# Altium schematic sheet PIC.SchDoc
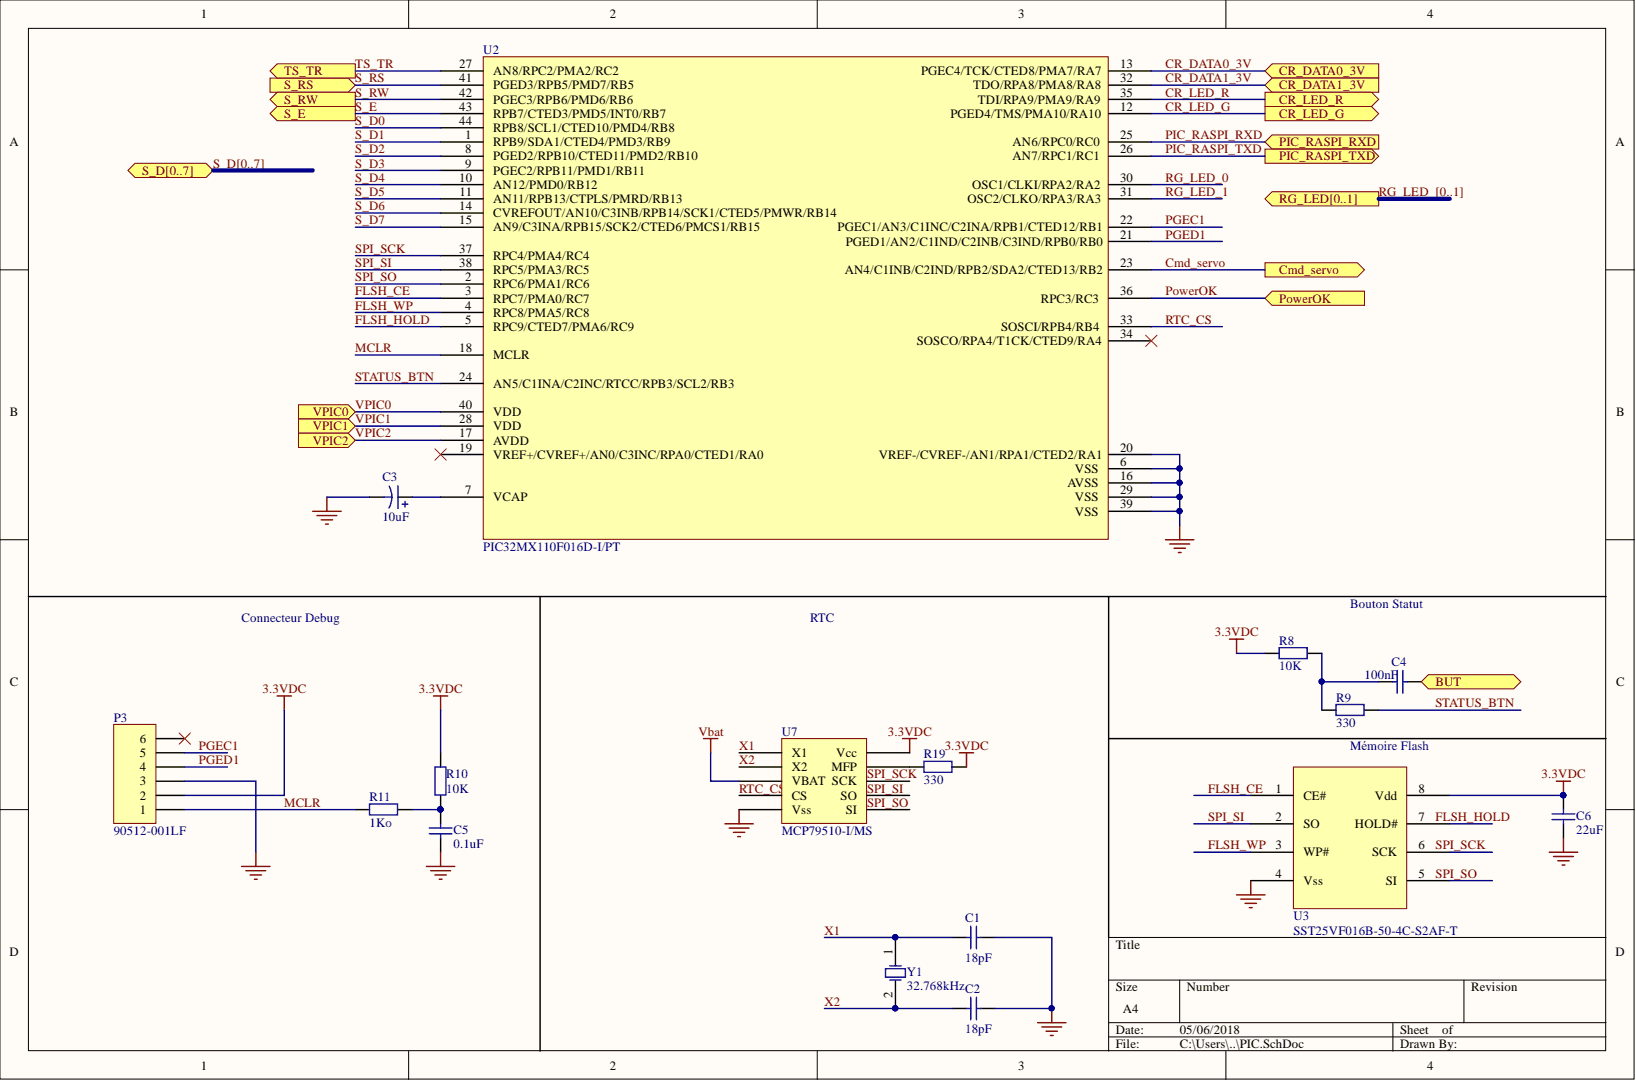
[diagram p0: PIC.SchDoc]

## Parts
C1  18pF  [0603]
C2  18pF  [0603]
C3  10uF  [C3]
C4  100nF  [0603]
C5  0.1uF  [0603]
C6  22uF  [0603]
P3  90512-001LF  [RJ12]
R8  10K  [0603]
R9  330  [0603]
R10  10K  [0603]
R11  1Ko  [0603]
R19  330  [0603]
U2  PIC32MX110F016D-I/PT  [TQFP-44_L]  (value not drawn)
U3  SST25VF016B-50-4C-S2AF-T  [SOIC208-8_L]
U7  MCP79510-I/MS  [MICROCHIP MSOP-10 UN]
Y1  32.768kHz  [QUARTZ32KHz]

## Ports
BUT, Cmd_servo, CR_DATA0_3V, CR_DATA1_3V, CR_LED_G, CR_LED_R, PIC_RASPI_RXD, PIC_RASPI_TXD, PowerOK, RG_LED0, RG_LED1, RG_LED[0..1], S_D0, S_D1, S_D2, S_D3, S_D4, S_D5, S_D6, S_D7, S_D[0..7], S_E, S_RS, S_RW, TS_TR, VPIC0, VPIC1, VPIC2

## Nets
3.3VDC: C6.2 P3.2 R8.1 R10.2 R19.2 U3.8(Vdd) U7.10(Vcc)
GND: C1.1 C2.1 C3.2 C5.1 C6.1 P3.3 U2.6(VSS) U2.16(AVSS) U2.20(VREF-/CVREF-/AN1/RPA1/CTED2/RA1) U2.29(VSS) U2.39(VSS) U3.4(Vss) U7.5(Vss)
Vbat: U7.3(VBAT)
BUT: C4.2 ; port BUT
Cmd_servo: U2.23(AN4/C1INB/C2IND/RPB2/SDA2/CTED13/RB2) ; port Cmd_servo
CR_DATA0_3V: U2.13(PGEC4/TCK/CTED8/PMA7/RA7) ; port CR_DATA0_3V
CR_DATA1_3V: U2.32(TDO/RPA8/PMA8/RA8) ; port CR_DATA1_3V
CR_LED_G: U2.12(PGED4/TMS/PMA10/RA10) ; port CR_LED_G
CR_LED_R: U2.35(TDI/RPA9/PMA9/RA9) ; port CR_LED_R
FLSH_CE: U2.3(RPC7/PMA0/RC7) U3.1(CE#)
FLSH_HOLD: U2.5(RPC9/CTED7/PMA6/RC9)
FLSH_WP: U2.4(RPC8/PMA5/RC8) U3.3(WP#)
MCLR: P3.1 R11.2 U2.18(MCLR)
PGEC1: P3.5 U2.22(PGEC1/AN3/C1INC/C2INA/RPB1/CTED12/RB1)
PGED1: P3.4 U2.21(PGED1/AN2/C1IND/C2INB/C3IND/RPB0/RB0)
PIC_RASPI_RXD: U2.25(AN6/RPC0/RC0) ; port PIC_RASPI_RXD
PIC_RASPI_TXD: U2.26(AN7/RPC1/RC1) ; port PIC_RASPI_TXD
PowerOK: U2.36(RPC3/RC3) ; port PowerOK
RG_LED_0: U2.30(OSC1/CLKI/RPA2/RA2) ; port RG_LED[0..1]  [also labelled RG_LED_]
RG_LED_1: U2.31(OSC2/CLKO/RPA3/RA3) ; port RG_LED[0..1]  [also labelled RG_LED_]
RTC_CS: U2.33(SOSCI/RPB4/RB4) U7.4(CS)
S_D0: U2.44(RPB8/SCL1/CTED10/PMD4/RB8) ; port S_D[0..7]  [also labelled S_D]
S_D1: U2.1(RPB9/SDA1/CTED4/PMD3/RB9) ; port S_D[0..7]  [also labelled S_D]
S_D2: U2.8(PGED2/RPB10/CTED11/PMD2/RB10) ; port S_D[0..7]  [also labelled S_D]
S_D3: U2.9(PGEC2/RPB11/PMD1/RB11) ; port S_D[0..7]  [also labelled S_D]
S_D4: U2.10(AN12/PMD0/RB12) ; port S_D[0..7]  [also labelled S_D]
S_D5: U2.11(AN11/RPB13/CTPLS/PMRD/RB13) ; port S_D[0..7]  [also labelled S_D]
S_D6: U2.14(CVREFOUT/AN10/C3INB/RPB14/SCK1/CTED5/PMWR/RB14) ; port S_D[0..7]  [also labelled S_D]
S_D7: U2.15(AN9/C3INA/RPB15/SCK2/CTED6/PMCS1/RB15) ; port S_D[0..7]  [also labelled S_D]
S_E: U2.43(RPB7/CTED3/PMD5/INT0/RB7) ; port S_E
S_RS: U2.41(PGED3/RPB5/PMD7/RB5) ; port S_RS
S_RW: U2.42(PGEC3/RPB6/PMD6/RB6) ; port S_RW
SPI_SCK: U2.37(RPC4/PMA4/RC4)
SPI_SI: U2.38(RPC5/PMA3/RC5) U3.2(SO)
SPI_SO: U2.2(RPC6/PMA1/RC6)
STATUS_BTN: R9.2 U2.24(AN5/C1INA/C2INC/RTCC/RPB3/SCL2/RB3)
TS_TR: U2.27(AN8/RPC2/PMA2/RC2) ; port TS_TR
VPIC0: U2.40(VDD) ; port VPIC0
VPIC1: U2.28(VDD) ; port VPIC1
VPIC2: U2.17(AVDD) ; port VPIC2
X1: C1.2 U7.1(X1) Y1.1
X2: C2.2 U7.2(X2) Y1.2
NetC3_1: C3.1 U2.7(VCAP)
NetC4_1: C4.1 R8.2 R9.1
NetC5_2: C5.2 R10.1 R11.1
NetR19_1: R19.1 U7.9(MFP)

Not connected: P3 6; U2 19(VREF+/CVREF+/AN0/C3INC/RPA0/CTED1/RA0) 34(SOSCO/RPA4/T1CK/CTED9/RA4); U3 5(SI) 6(SCK) 7(HOLD#); U7 6(SI) 7(SO) 8(SCK)

## Library data of the parts
C1 C2 = Cap: Capacitor; Fabricant: AVX; Fournisseur: Farnell; Ref.Fabricant: 02013A180JAT2A; Ref.Fournisseur: 1740563; Component Kind: Standard; Library Name: Miscellaneous Devices.IntLib; Signal Integrity: Cap; Simulation: CAP
C3 = Cap Pol1: Fabricant: VISHAY; Fournisseur: Farnell; Ref.Fabricant: TL3C106K025C0450..; Ref.Fournisseur: 2353238; Component Kind: Standard; Library Name: Miscellaneous Devices.IntLib; Signal Integrity: Cap Pol; Simulation: CAP
C4 = Cap: Capacitor; Fabricant: WURTH ELECTRONIK; Fournisseur: Farnell; Ref.Fabricant: 885342211005; Ref.Fournisseur: 2812613; Component Kind: Standard; Library Name: Miscellaneous Devices.IntLib; Signal Integrity: Cap; Simulation: CAP; Value: 100nF
C5 = Cap: Capacitor; Fabricant: Multicomp; Fournisseur: Farnell; Ref.Fabricant: MCY4C3B104M160CT; Ref.Fournisseur: 2310273; Component Kind: Standard; Library Name: Miscellaneous Devices.IntLib; Signal Integrity: Cap; Simulation: CAP; Value: 0.1uF
C6 = Cap: Cout_Reg_3.3v; Fabricant: AVX; Fournisseur: Farnell; Ref.Fabricant: 12103D226KAT2A; Ref.Fournisseur: 1833829; Component Kind: Standard; Library Name: Miscellaneous Devices.IntLib; Signal Integrity: Cap; Simulation: CAP; Value: 22uF
P3 = Header 6: PortRJ12_debug; Fabricant: Amphenol ICC; Fournisseur: Farnell; Ref.Fournisseur: 1097914; Component Kind: Standard; Library Name: Miscellaneous Connectors.IntLib; Ref.Fabricant: 90512-001LF; Signal Integrity: Connector
R8 R10 = Res2: Resistor; Fabricant: TE CONNECTIVITY; Fournisseur: Farnell; Ref.Fabricant: CPF0201D10KC1; Ref.Fournisseur: 2117887; Component Kind: Standard; Library Name: Miscellaneous Devices.IntLib; Signal Integrity: Res; Simulation: RESISTOR
  R10: Value: 10k
R9 R19 = Res2: Resistor; Fabricant: TE Connectivity; Fournisseur: Farnell; Ref.Fabricant: RN73C1J232RBTDF; Ref.Fournisseur: 2687973; Component Kind: Standard; Library Name: Miscellaneous Devices.IntLib; Signal Integrity: Res; Simulation: RESISTOR
R11 = Res2: Resistor; Fabricant: TE CONNECTIVITY; Fournisseur: Farnell; Ref.Fabricant: CPF0201D1K0E1; Ref.Fournisseur: 2117780; Value: 1K; Component Kind: Standard; Library Name: Miscellaneous Devices.IntLib; Signal Integrity: Res; Simulation: RESISTOR
U2 = PIC32: Fabricant: MICROCHIP; Fournisseur: Farnell; Ref.Fournisseur: 2057068; Component Kind: Standard; Description: PIC32MX110F016D-I/PT; Library Name: Schlib1.SchLib; Ref.Fabricant: PIC32MX110F016D-I/PT
U3 = Memoire: FLASH; Fabricant: Microchip; Fournisseur: Farnell; Ref.Fabricant: SST25VF016B-50-4I-S2AF-T; Ref.Fournisseur: 2774943; Component Kind: Standard; Library Name: Schlib1.SchLib
U7 = MCP79510: RTC; Fabricant: Microchip; Fournisseur: Farnell; Ref.Fournisseur: 2361124; Component Kind: Standard; Library Name: Schlib1.SchLib; Ref.Fabricant: MCP79510-I/MS
Y1 = XTAL: Crystal Oscillator; Fabricant: ABRACON; Fournisseur: Farnell; Ref.Fabricant: ABS07-32.768KHZ-7-T; Ref.Fournisseur: 1838935; Component Kind: Standard; Library Name: Miscellaneous Devices.IntLib; Simulation: XTAL
